# Altium schematic sheet LR1110_e595v03a_MCU.SchDoc(LR1110_e595v03a_MCU)
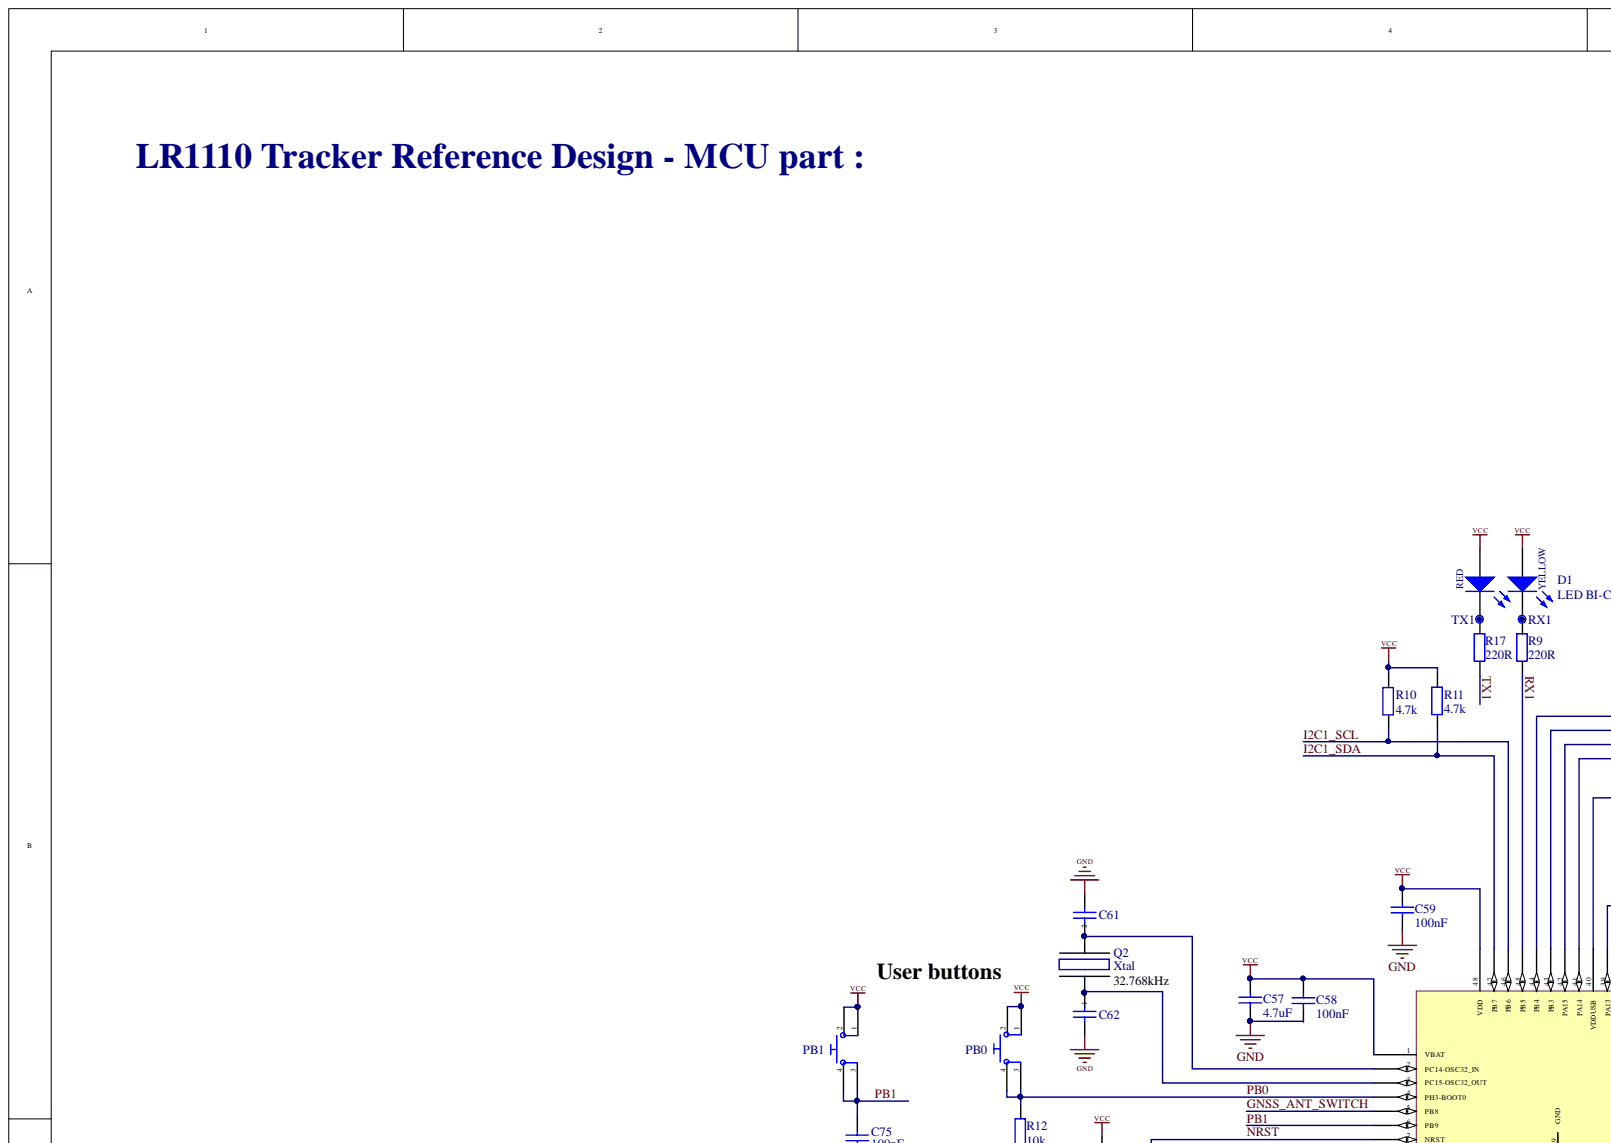
[diagram p0: LR1110_e595v03a_MCU.SchDoc, top left]
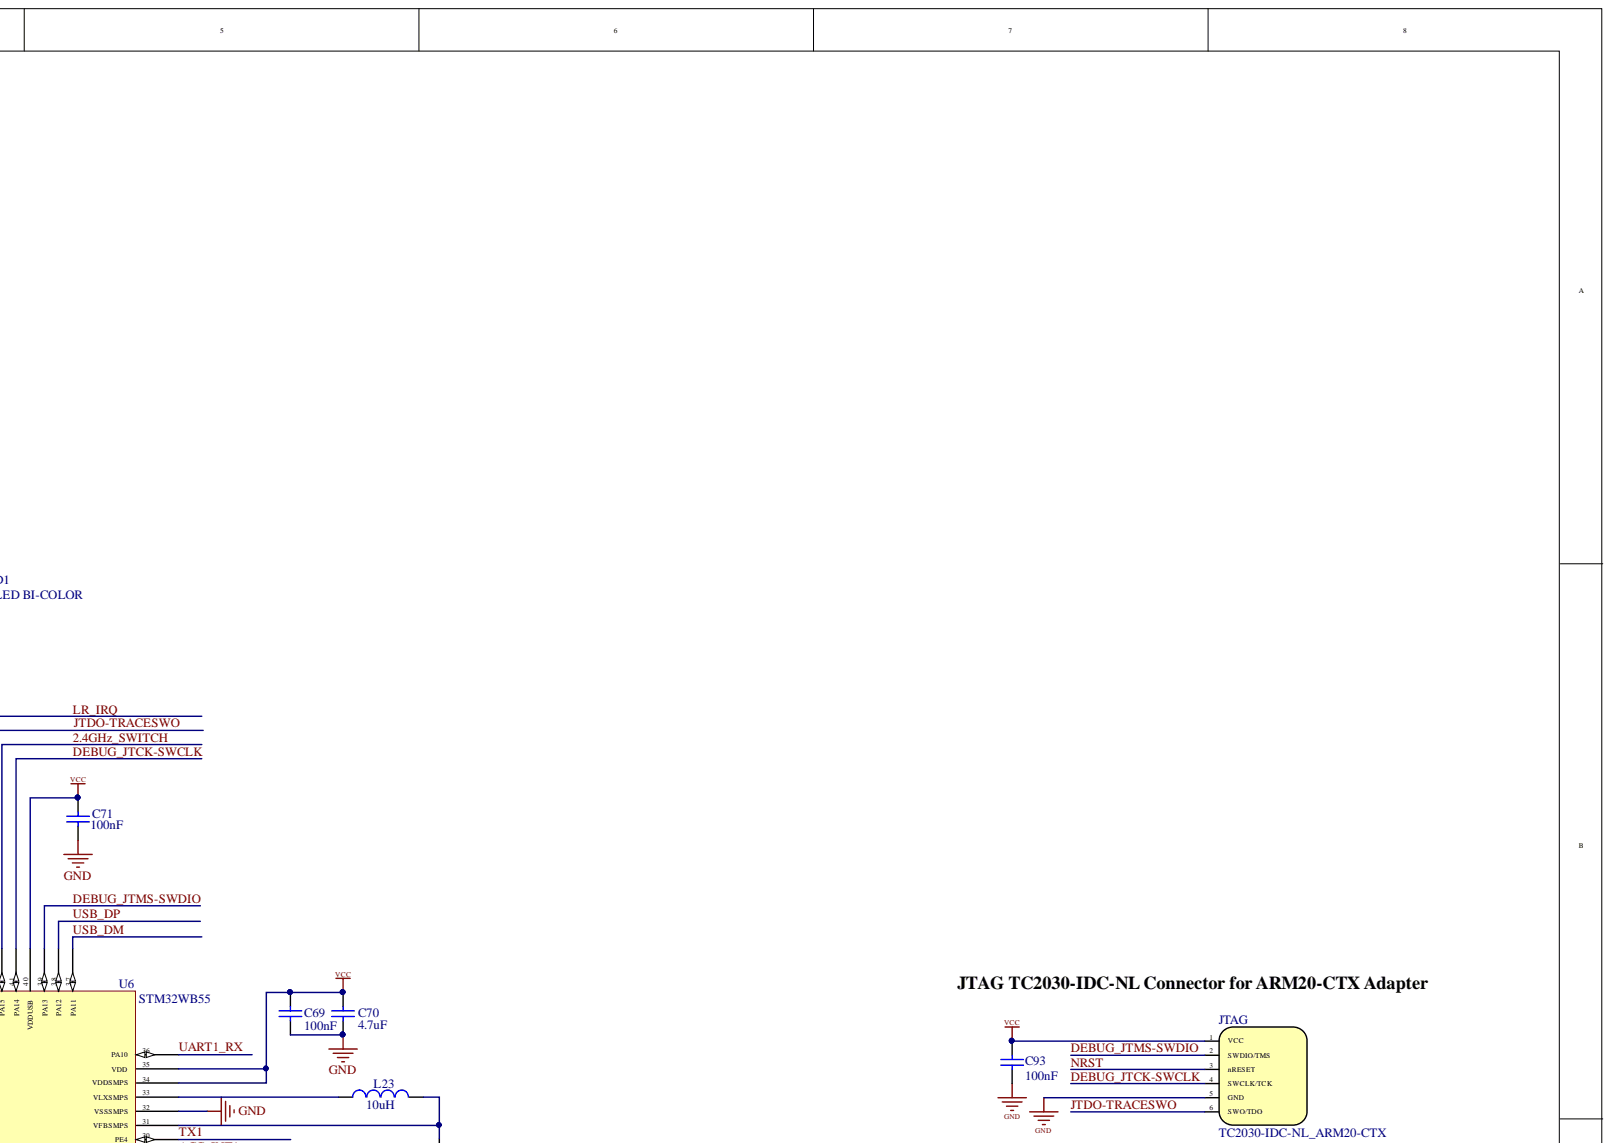
[diagram p1: LR1110_e595v03a_MCU.SchDoc, top right]
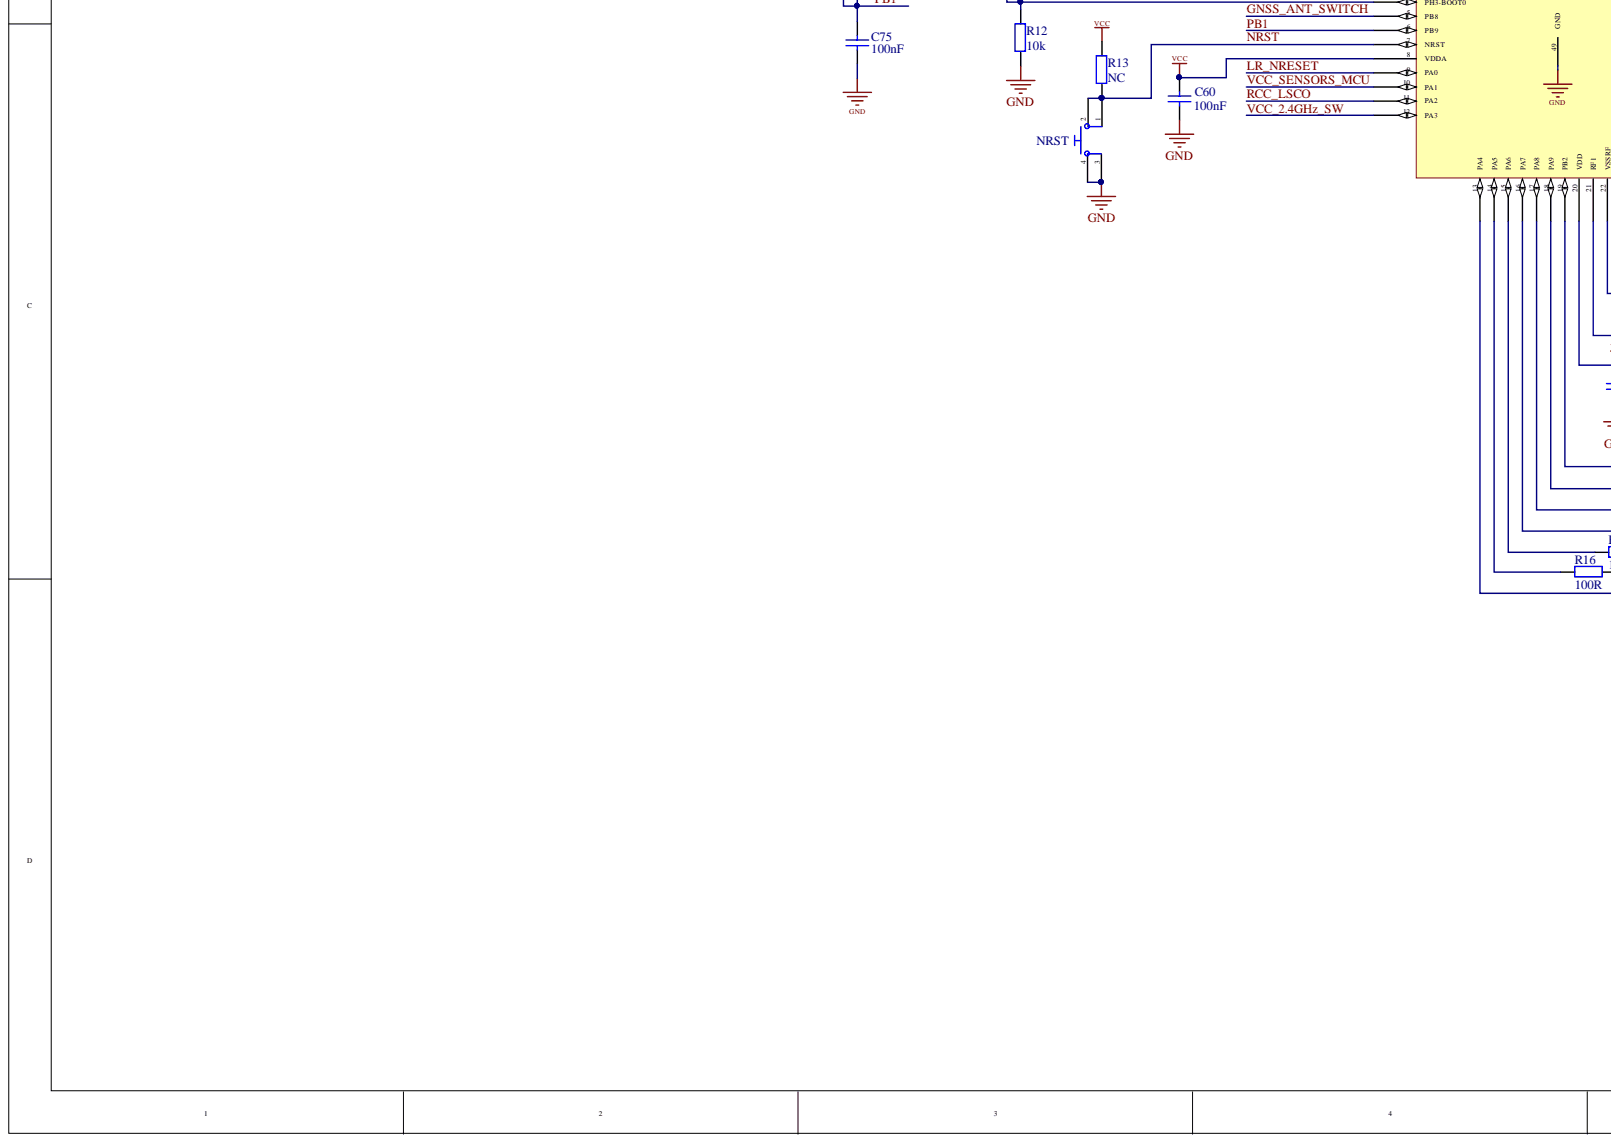
[diagram p2: LR1110_e595v03a_MCU.SchDoc, bottom left]
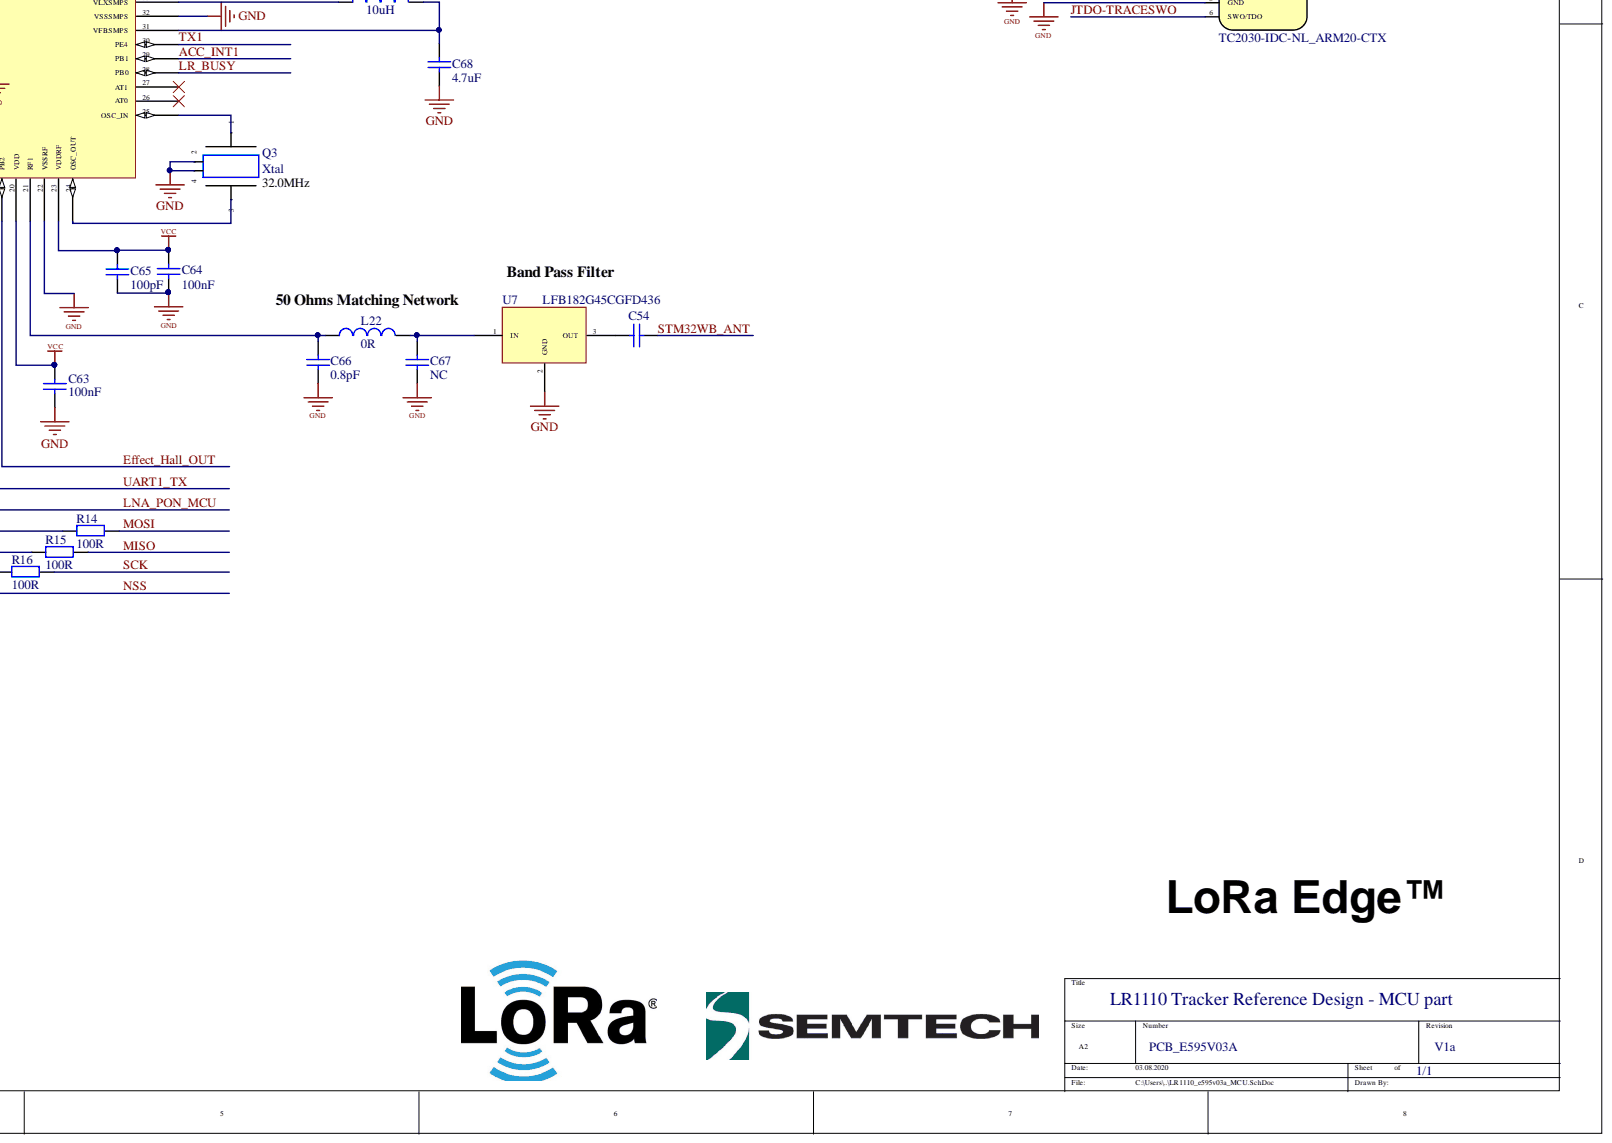
[diagram p3: LR1110_e595v03a_MCU.SchDoc, bottom right]

## Parts
C54  Cap  [rlc_201_smd_small]  (value not drawn)
C57  value 4.7uF  [rlc_402_smd_small]  (hidden comment: Cap)
C58  value 100nF  [rlc_201_smd_small]  (hidden comment: Cap)
C59  value 100nF  [rlc_201_smd_small]  (hidden comment: Cap)
C60  value 100nF  [rlc_201_smd_small]  (hidden comment: Cap)
C61  Cap  [rlc_201_smd_small]  (value not drawn)
C62  Cap  [rlc_201_smd_small]  (value not drawn)
C63  value 100nF  [rlc_201_smd_small]  (hidden comment: Cap)
C64  value 100nF  [rlc_201_smd_small]  (hidden comment: Cap)
C65  value 100pF  [rlc_201_smd_small]  (hidden comment: Cap)
C66  value 0.8pF  [rlc_201_smd_small]  (hidden comment: Cap)
C67  value NC  [rlc_201_smd_small]  (hidden comment: Cap)
C68  value 4.7uF  [rlc_402_smd_small]  (hidden comment: Cap)
C69  value 100nF  [rlc_201_smd_small]  (hidden comment: Cap)
C70  value 4.7uF  [rlc_402_smd_small]  (hidden comment: Cap)
C71  value 100nF  [rlc_201_smd_small]  (hidden comment: Cap)
C75  value 100nF  [rlc_201_smd_small]  (hidden comment: Cap)
C93  value 100nF  [rlc_201_smd_small]  (hidden comment: Cap)
D1  LED BI-COLOR  [CD1608-0603-LED]
JTAG  TC2030-IDC-NL_ARM20-CTX  [TC2030-MCP-NL]  (no BOM)
L22  value 0R  [rlc_201_smd_small]  (hidden comment: Inductor)
L23  value 10uH  [rlc_603_smd_small]  (hidden comment: Inductor)
NRST  SW_PB_2S  [EVPBB0AAB000_series_switch_2.6x1.6mm]  (value not drawn)
PB0  SW_PB_2S  [EVPBB0AAB000_series_switch_2.6x1.6mm]  (value not drawn)
PB1  SW_PB_2S  [EVPBB0AAB000_series_switch_2.6x1.6mm]  (value not drawn)
Q2  Xtal  value 32.768kHz  [XTAL_2012_SMD]
Q3  Xtal  value 32.0MHz  [nd2016da]
R9  value 220R  [rlc_201_smd_small]  (hidden comment: Resistor)
R10  value 4.7k  [rlc_201_smd_small]  (hidden comment: Resistor)
R11  value 4.7k  [rlc_201_smd_small]  (hidden comment: Resistor)
R12  value 10k  [rlc_201_smd_small]  (hidden comment: Resistor)
R13  value NC  [rlc_201_smd_small]  (hidden comment: Resistor)
R14  value 100R  [rlc_201_smd_small]  (hidden comment: Resistor)
R15  value 100R  [rlc_201_smd_small]  (hidden comment: Resistor)
R16  value 100R  [rlc_201_smd_small]  (hidden comment: Resistor)
R17  value 220R  [rlc_201_smd_small]  (hidden comment: Resistor)
RX1  Test Pin  [PIN_SMD2]  (value not drawn)
TX1  Test Pin  [PIN_SMD2]  (value not drawn)
U6  STM32WB55  [UFQFPN48_7x7MM]
U7  LFB182G45CGFD436  [LFB182G45CGFD436]

## Nets
GND: C57.2 C58.1 C59.1 C60.2 C61.1 C62.2 C63.1 C64.1 C65.1 C66.1 C67.1 C68.2 C69.1 C70.2 C71.1 C75.2 C93.1 JTAG.5(GND) NRST.3 NRST.4 Q3.2 Q3.4 R12.1 U6.22(VSSRF) U6.32(VSSSMPS) U6.49(GND) U7.2
VCC: C57.1 C58.2 C59.2 C60.1 C63.2 C64.2 C65.2 C69.2 C70.1 C71.2 C93.2 D1.1 D1.3 JTAG.1(VCC) PB0.1 PB0.2 PB1.1 PB1.2 R10.1 R11.2 R13.2 U6.1(VBAT) U6.8(VDDA) U6.20(VDD) U6.23(VDDRF) U6.34(VDDSMPS) U6.35(VDD) U6.40(VDDUSB) U6.48(VDD)
2.4GHz_SWITCH: U6.42
ACC_INT1: U6.29(PB1)
DEBUG_JTCK-SWCLK: JTAG.4(SWCLK/TCK) U6.41(PA14)
DEBUG_JTMS-SWDIO: JTAG.2(SWDIO/TMS) U6.39(PA13)
Effect_Hall_OUT: U6.19
GNSS_ANT_SWITCH: U6.5(PB8)
I2C1_SCL: R10.2 U6.46
I2C1_SDA: R11.1 U6.47(PB7)
JTDO-TRACESWO: JTAG.6(SWO/TDO) U6.43(PB3)
LNA_PON_MCU: U6.17(PA8)
LR_BUSY: U6.28(PB0)
LR_IRQ: U6.44(PB4)
LR_NRESET: U6.9(PA0)
MISO: R15.1
MOSI: R14.1
NRST: JTAG.3(nRESET) NRST.1 NRST.2 R13.1 U6.7(NRST)
NSS: U6.13(PA4)
PB0: PB0.3 PB0.4 R12.2 U6.4(PH3-BOOT0)
PB1: C75.1 PB1.3 PB1.4 U6.6(PB9)
RCC_LSCO: U6.11(PA2)
RX1: R9.1 U6.45(PB5)
SCK: R16.1
STM32WB_ANT: C54.1
TX1: R17.1 U6.30(PE4)
UART1_RX: U6.36(PA10)
UART1_TX: U6.18(PA9)
USB_DM: U6.37(PA11)
USB_DP: U6.38(PA12)
VCC_2.4GHz_SW: U6.12(PA3)
VCC_SENSORS_MCU: U6.10(PA1)
NetC54_2: C54.2 U7.3
NetC61_2: C61.2 Q2.2 U6.2(PC14-OSC32_IN)
NetC62_1: C62.1 Q2.1 U6.3(PC15-OSC32_OUT)
NetC66_2: C66.2 L22.1 U6.21(RF1)
NetC67_2: C67.2 L22.2 U7.1
NetC68_1: C68.1 L23.2 U6.31(VFBSMPS)
NetD1_2: D1.2 R17.2 TX1.1
NetD1_4: D1.4 R9.2 RX1.1
NetL23_1: L23.1 U6.33(VLXSMPS)
NetQ3_1: Q3.1 U6.25(OSC_IN)
NetQ3_3: Q3.3 U6.24(OSC_OUT)
NetR14_2: R14.2 U6.16(PA7)
NetR15_2: R15.2 U6.15
NetR16_2: R16.2 U6.14(PA5)

Not connected: U6 26(AT0) 27(AT1)

## Library data of the parts
C54 = Cap: Capacitor SMD; Value: 47pF; Simulation: CAP; Signal Integrity: Cap Semi; Published: 8-Jun-2000; Library Name: LR1110_e595v03a.SchLib; LatestRevisionNote: Re-released for DXP Platform.; LatestRevisionDate: 05-oct-2007  -  Semtech; Component Kind: Standard; Publisher: Altium Limited
C57-C71 C75 C93 = Cap: Capacitor SMD; Simulation: CAP; Signal Integrity: Cap Semi; Published: 8-Jun-2000; Library Name: LR1110_e595v03a.SchLib; LatestRevisionNote: Re-released for DXP Platform.; LatestRevisionDate: 05-oct-2007  -  Semtech; Component Kind: Standard; Publisher: Altium Limited
  C61 C62: Value: ?
D1 = LED BI COLOR: Library Name: LR1110_e595v03a.SchLib; LatestRevisionDate: 05-oct-2007  -  Semtech; Description: LED BI COLOR; Component Kind: Standard
JTAG = TC2030-IDC-NL_ARM20-CTX: TC2030-MCP-NL for ARM20-CTX; Library Name: LR1110_e595v03a.SchLib
L22 L23 = Inductor: Code_IEC: 1005; Published: 8-Jun-2000; Library Name: LR1110_e595v03a.SchLib; LatestRevisionNote: Re-released for DXP Platform.; LatestRevisionDate: 05-oct-2007  -  Semtech; Description: Inductor; Component Kind: Standard; Code_JEITA: 0402; Publisher: Altium Limited
NRST PB0 PB1 = SW-PB_2S: Subminiature Toggle Switch, Right Angle Mounting, Vertical Actuation; Published: 03-Jul-2017; Library Name: LR1110_e595v03a.SchLib; LatestRevisionNote: Schematic revised, PCB Footprint replaced.; LatestRevisionDate: 03-Jul-2017; DatasheetDocument: 03-Jul-2017; ComponentLink1Description: Manufacturer Link; Component Kind: Standard; Publisher: Altium Limited
Q2 = Xtal: Crystal oscillator; Library Name: LR1110_e595v03a.SchLib; LatestRevisionDate: 05-oct-2007  -  Semtech; Component Kind: Standard
Q3 = Xtal GND: Crystal with GND pins; Library Name: LR1110_e595v03a.SchLib; LatestRevisionDate: 05-oct-2007  -  Semtech; Component Kind: Standard
R9-R17 = Resistor: Resistor SMD - IEC symbol version; Library Name: LR1110_e595v03a.SchLib; LatestRevisionDate: 27-Jul-20  -  Semtech; Component Kind: Standard
RX1 TX1 = test_pin: Library Name: LR1110_e595v03a.SchLib; LatestRevisionDate: 05-oct-2007  -  Semtech; Description: Test Pin; Component Kind: Standard
U6 = STM32WB55: Multiprotocol wireless 32-bit MCU Arm®-based Cortex®-M4 with FPU, Bluetooth® 5 and 802.15.4 radio solution; Library Name: LR1110_e595v03a.SchLib; Component Kind: Standard
U7 = LFB182G45CGFD436: Murata band pass filter; Library Name: LR1110_e595v03a.SchLib; Component Kind: Standard
